# Altium schematic sheet MCU.SchDoc(MCU)
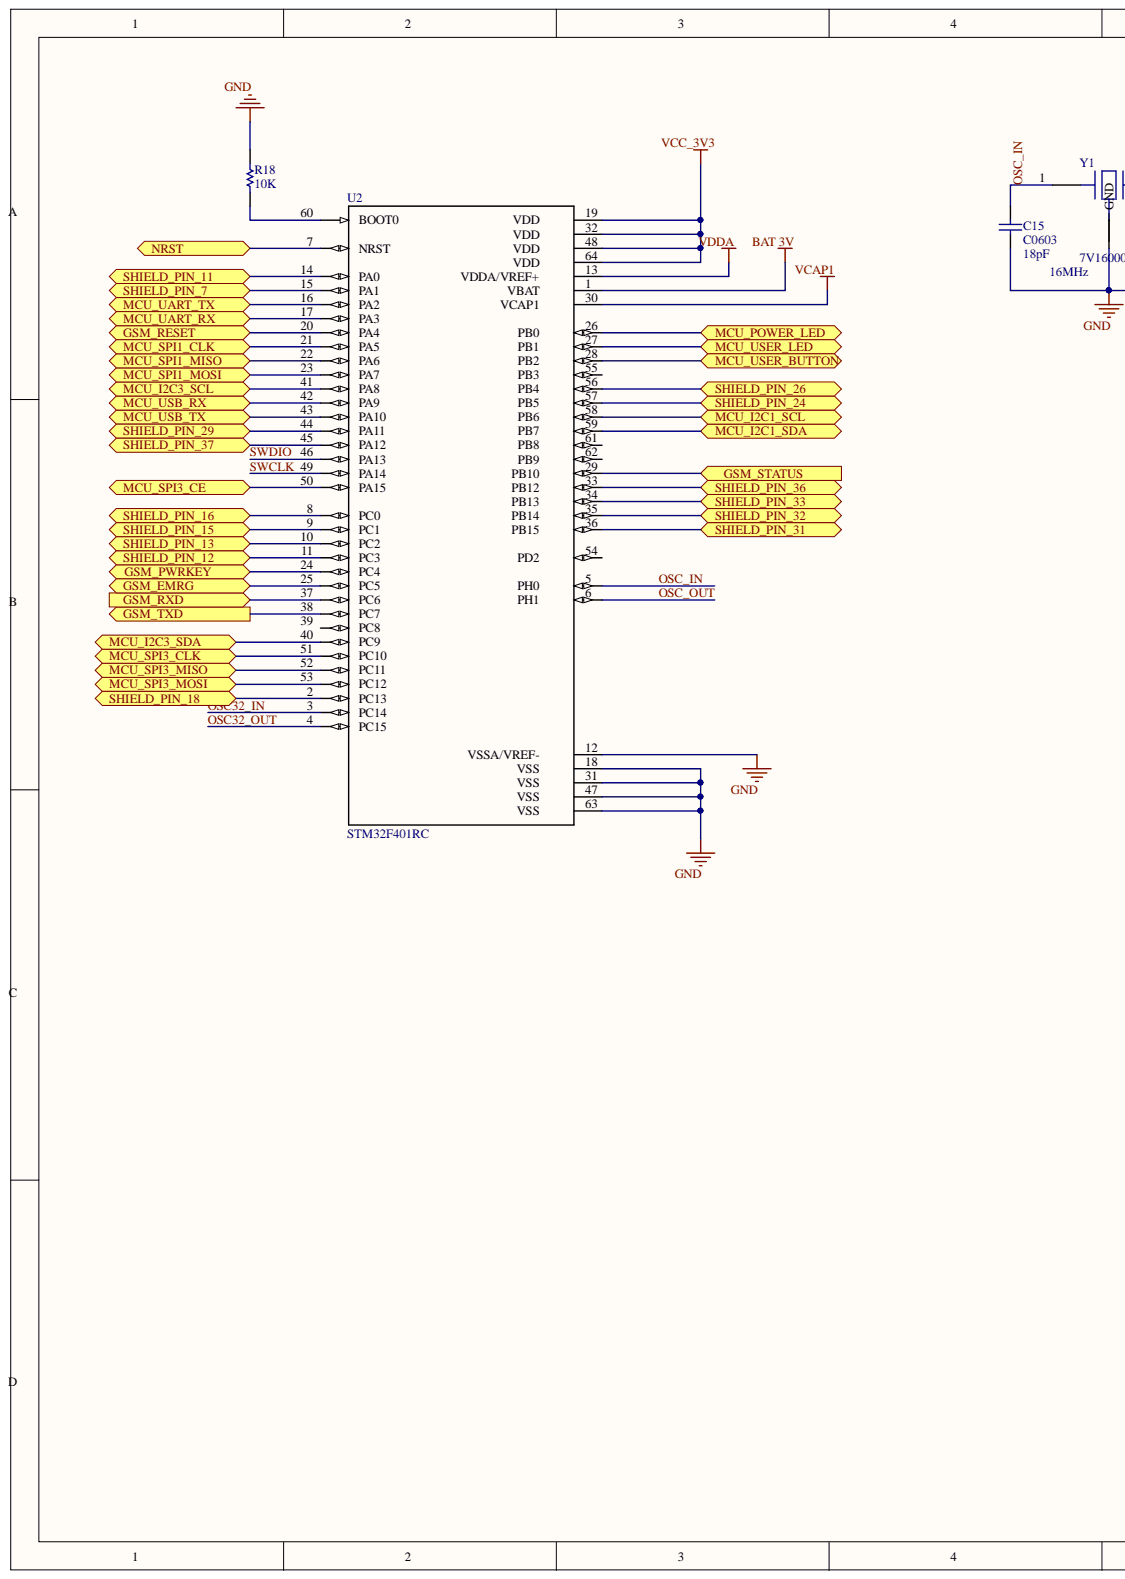
[diagram p0: MCU.SchDoc, left]
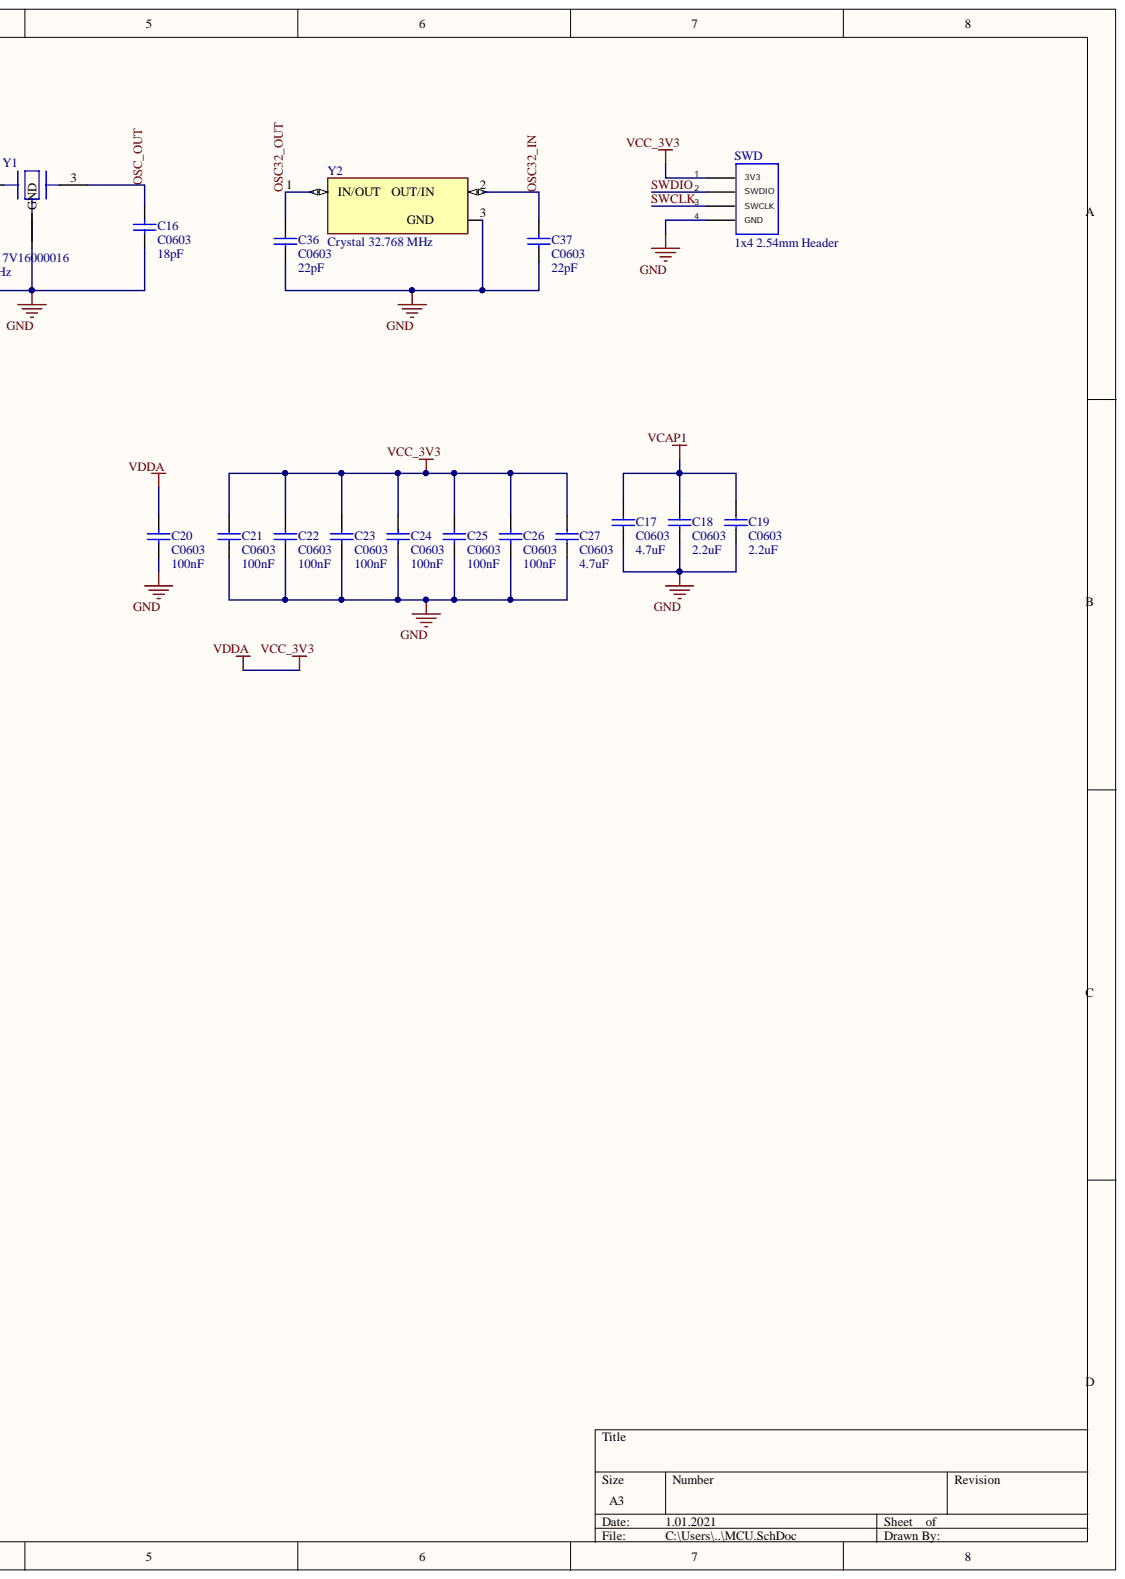
[diagram p1: MCU.SchDoc, right]

## Parts
C15  C0603  value 18pF  [C0603]
C16  C0603  value 18pF  [C0603]
C17  C0603  value 4.7uF  [C0603]
C18  C0603  value 2.2uF  [C0603]
C19  C0603  value 2.2uF  [C0603]
C20  C0603  value 100nF  [C0603]
C21  C0603  value 100nF  [C0603]
C22  C0603  value 100nF  [C0603]
C23  C0603  value 100nF  [C0603]
C24  C0603  value 100nF  [C0603]
C25  C0603  value 100nF  [C0603]
C26  C0603  value 100nF  [C0603]
C27  C0603  value 4.7uF  [C0603]
C36  C0603  value 22pF  [C0603]
C37  C0603  value 22pF  [C0603]
R18  value 10K  [CC1608-0603]  (hidden comment: Res0603)
SWD  1x4 2.54mm Header  [1x4 2.54mm Header]
U2  STM32F401RC  [STM32F401RE]
Y1  7V16000016  value 16MHz  [7V16000016]
Y2  Crystal 32.768 MHz  [Crystal 32.768 MHz]

## Ports
GSM_EMRG, GSM_PWRKEY, GSM_RESET, GSM_RXD, GSM_STATUS, GSM_TXD, MCU_I2C1_SCL, MCU_I2C1_SDA, MCU_I2C3_SCL, MCU_I2C3_SDA, MCU_POWER_LED, MCU_SPI1_CLK, MCU_SPI1_MISO, MCU_SPI1_MOSI, MCU_SPI3_CE, MCU_SPI3_CLK, MCU_SPI3_MISO, MCU_SPI3_MOSI, MCU_UART_RX, MCU_UART_TX, MCU_USB_RX, MCU_USB_TX, MCU_USER_BUTTON, MCU_USER_LED, NRST, SHIELD_PIN_7, SHIELD_PIN_11, SHIELD_PIN_12, SHIELD_PIN_13, SHIELD_PIN_15, SHIELD_PIN_16, SHIELD_PIN_18, SHIELD_PIN_24, SHIELD_PIN_26, SHIELD_PIN_29, SHIELD_PIN_31, SHIELD_PIN_32, SHIELD_PIN_33, SHIELD_PIN_36, SHIELD_PIN_37

## Nets
BAT 3V: U2.1
GND: C15.1 C16.1 C17.2 C18.2 C19.2 C20.2 C21.1 C22.1 C23.1 C24.1 C25.1 C26.1 C27.1 C36.2 C37.2 R18.1 SWD.4(GND) U2.12 U2.18 U2.31 U2.47 U2.63 Y1.2 Y2.3
VCAP1: C17.1 C18.1 C19.1 U2.30
VCC_3V3: C20.1 C21.2 C22.2 C23.2 C24.2 C25.2 C26.2 C27.2 SWD.1(3V3) U2.13 U2.19 U2.32 U2.48 U2.64
GSM_EMRG: U2.25(PC5) ; port GSM_EMRG
GSM_PWRKEY: U2.24(PC4) ; port GSM_PWRKEY
GSM_RESET: U2.20(PA4) ; port GSM_RESET
GSM_RXD: U2.37(PC6) ; port GSM_RXD
GSM_STATUS: U2.29 ; port GSM_STATUS
GSM_TXD: U2.38(PC7) ; port GSM_TXD
MCU_I2C1_SCL: U2.58 ; port MCU_I2C1_SCL
MCU_I2C1_SDA: U2.59 ; port MCU_I2C1_SDA
MCU_I2C3_SCL: U2.41(PA8) ; port MCU_I2C3_SCL
MCU_I2C3_SDA: U2.40(PC9) ; port MCU_I2C3_SDA
MCU_POWER_LED: U2.26 ; port MCU_POWER_LED
MCU_SPI1_CLK: U2.21(PA5) ; port MCU_SPI1_CLK
MCU_SPI1_MISO: U2.22(PA6) ; port MCU_SPI1_MISO
MCU_SPI1_MOSI: U2.23(PA7) ; port MCU_SPI1_MOSI
MCU_SPI3_CE: U2.50(PA15) ; port MCU_SPI3_CE
MCU_SPI3_CLK: U2.51(PC10) ; port MCU_SPI3_CLK
MCU_SPI3_MISO: U2.52(PC11) ; port MCU_SPI3_MISO
MCU_SPI3_MOSI: U2.53(PC12) ; port MCU_SPI3_MOSI
MCU_UART_RX: U2.17(PA3) ; port MCU_UART_RX
MCU_UART_TX: U2.16(PA2) ; port MCU_UART_TX
MCU_USB_RX: U2.42(PA9) ; port MCU_USB_RX
MCU_USB_TX: U2.43(PA10) ; port MCU_USB_TX
MCU_USER_BUTTON: U2.28 ; port MCU_USER_BUTTON
MCU_USER_LED: U2.27 ; port MCU_USER_LED
NRST: U2.7(NRST) ; port NRST
OSC32_IN: C37.1 U2.3(PC14) Y2.2
OSC32_OUT: C36.1 U2.4(PC15) Y2.1
OSC_IN: C15.2 U2.5 Y1.1
OSC_OUT: C16.2 U2.6 Y1.3
SHIELD_PIN_7: U2.15(PA1) ; port SHIELD_PIN_7
SHIELD_PIN_11: U2.14(PA0) ; port SHIELD_PIN_11
SHIELD_PIN_12: U2.11(PC3) ; port SHIELD_PIN_12
SHIELD_PIN_13: U2.10(PC2) ; port SHIELD_PIN_13
SHIELD_PIN_15: U2.9(PC1) ; port SHIELD_PIN_15
SHIELD_PIN_16: U2.8(PC0) ; port SHIELD_PIN_16
SHIELD_PIN_18: U2.2(PC13) ; port SHIELD_PIN_18
SHIELD_PIN_24: U2.57 ; port SHIELD_PIN_24
SHIELD_PIN_26: U2.56 ; port SHIELD_PIN_26
SHIELD_PIN_29: U2.44(PA11) ; port SHIELD_PIN_29
SHIELD_PIN_31: U2.36 ; port SHIELD_PIN_31
SHIELD_PIN_32: U2.35 ; port SHIELD_PIN_32
SHIELD_PIN_33: U2.34 ; port SHIELD_PIN_33
SHIELD_PIN_36: U2.33 ; port SHIELD_PIN_36
SHIELD_PIN_37: U2.45(PA12) ; port SHIELD_PIN_37
SWCLK: SWD.3(SWCLK) U2.49(PA14)
SWDIO: SWD.2(SWDIO) U2.46(PA13)
NetR18_2: R18.2 U2.60(BOOT0)

Not connected: U2 39(PC8) 54 55 61 62; Y1 4,1(GND)

## Library data of the parts
C15-C27 C36 C37 = C0603: Ceramic Chip Capacitor - Standard; Note: Footprint corresponds to dimensions for bulk cassette packaging. This case size has tightened tolerances.; Code_IPC: 1608 [0603]; Code_JEITA: 0603; Component Kind: Standard; ComponentLink1Description: Manufacturer Link; ComponentLink1URL: http://www.kemet.com/; ComponentLink2Description: Datasheet; ComponentLink2URL: http://www.kemet.com/kemet/web/homepage/kechome.nsf/vapubfilesname/F3102TA.pdf/$file/F3102TA.pdf; DatasheetDocument: Mar-2001; LatestRevisionDate: 19-Mar-2004; LatestRevisionNote: Silkscreen size reduced.; PackageDocument: Mar-2001; PackageReference: 0603; Published: 17-Jul-2002; Library Name: haberlesme.SchLib; Publisher: Altium Limited
R18 = Res0603: Resistor; Manufacturer: Generic Components; Class I: Passive; Class II: Resistor; Component Kind: Standard; Published: 8-Jun-2000; Revision: July-2002: Re-released for DXP Platform.; Signal Integrity: Res1; Simulation: RESISTOR; Library Name: Isbak.SchLib; Publisher: Altium Limited
U2 = STM32F401RE: Component Kind: Standard; Library Name: haberlesme.SchLib
SWD = 1x4 2.54mm Header: Component Kind: Standard; Library Name: haberlesme.SchLib
Y1 = 7V16000016: Component Kind: Standard; Library Name: haberlesme.SchLib
Y2 = Crystal 32.768 MHz: Component Kind: Standard; Library Name: haberlesme.SchLib
